# Altium schematic sheet Sensors.SchDoc(Sensors)
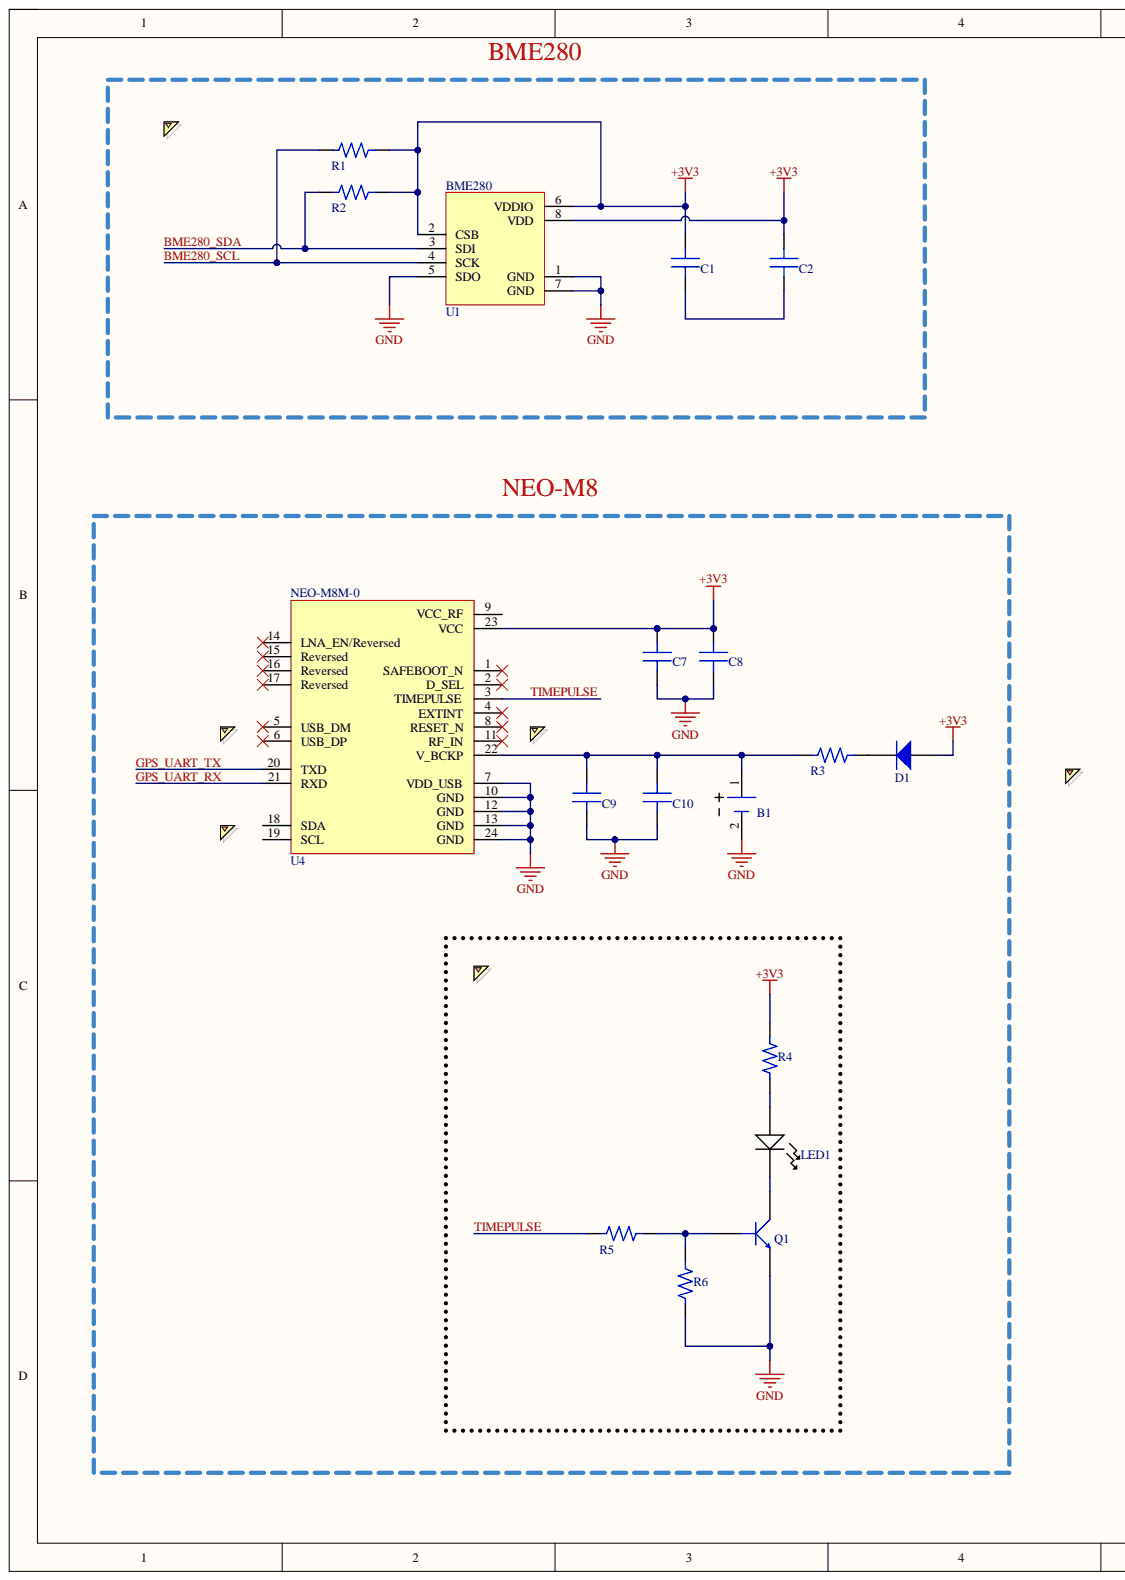
[diagram p0: Sensors.SchDoc, left]
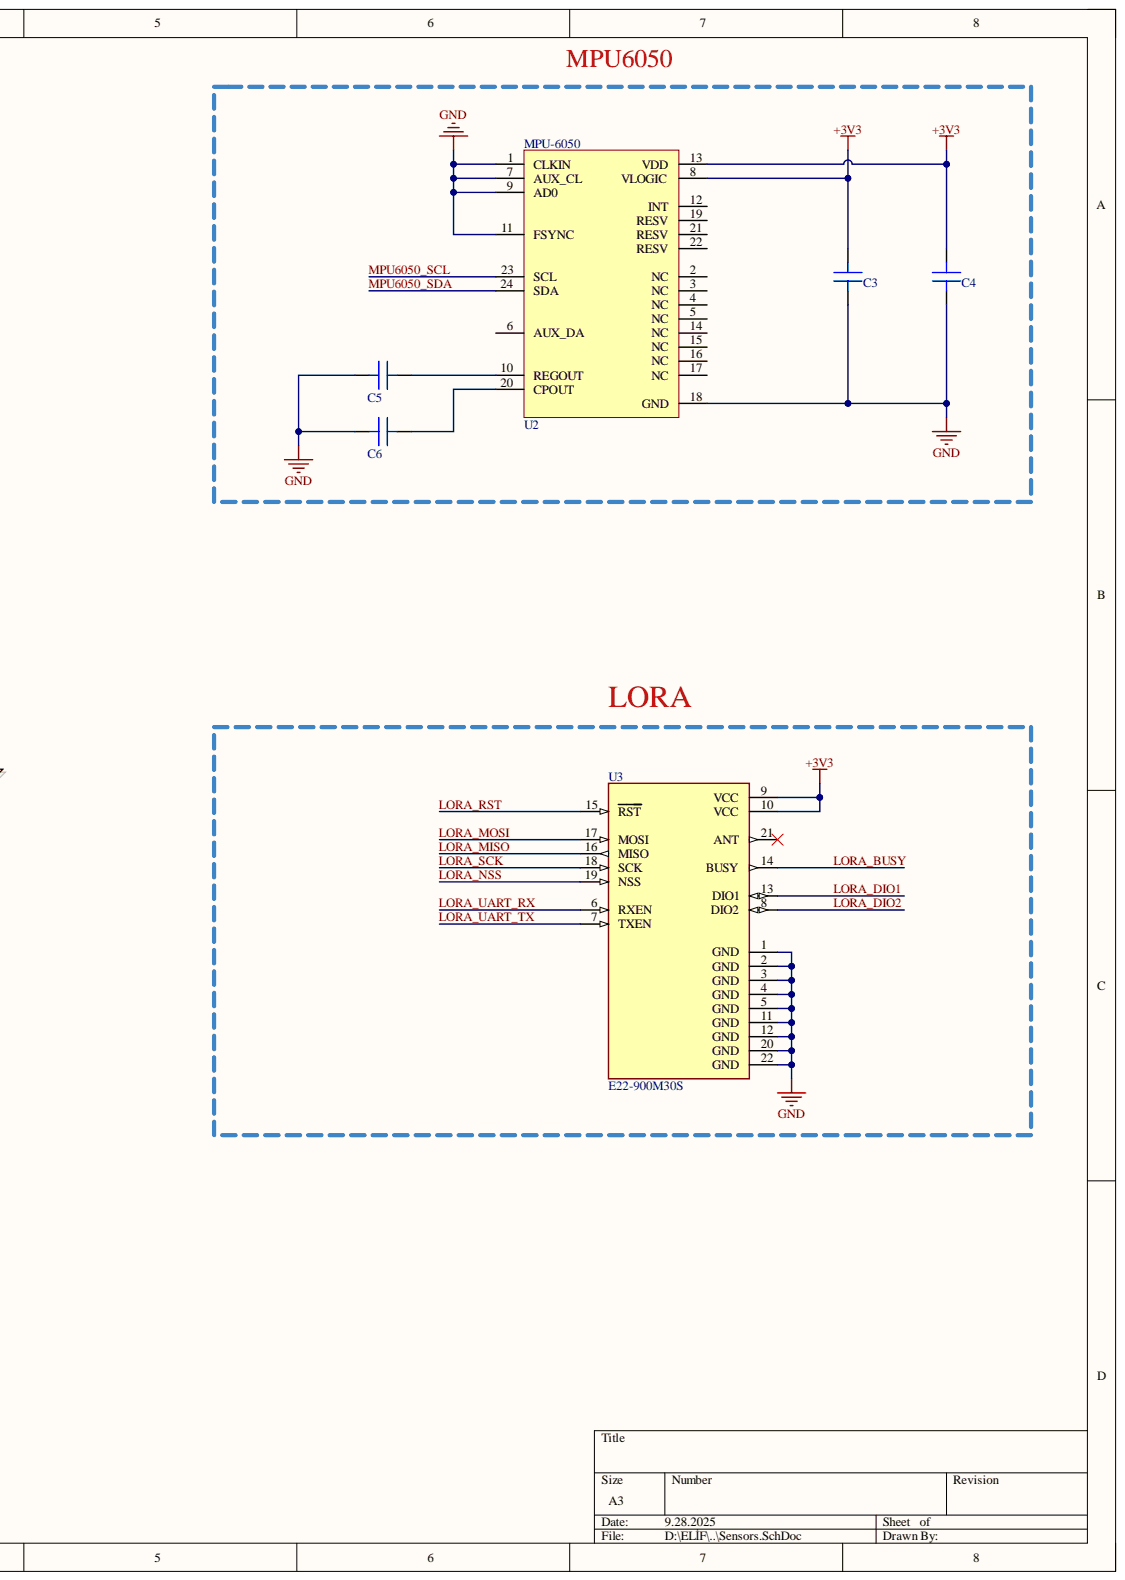
[diagram p1: Sensors.SchDoc, right]

## Parts
B1  MS920SE-FL27E  [MS920SE_Battery]  (value not drawn)
C1  CAP CER 100nF 0805  [CAP_0805]  (value not drawn)
C2  CAP CER 100nF 0805  [CAP_0805]  (value not drawn)
C3  CAP CER 10nF 0805  [CAP_0805]  (value not drawn)
C4  CAP CER 0.1uF 0805  [CAP_0805]  (value not drawn)
C5  CAP CER 0.1uF 0805  [CAP_0805]  (value not drawn)
C6  CAP CER 2.2nF 0805  [CAP_0805]  (value not drawn)
C7  CAP CER 10uF 0805  [CAP_0805]  (value not drawn)
C8  CAP CER 100nF 0805  [CAP_0805]  (value not drawn)
C9  CAP CER 4.7uF 0805  [CAP_0805]  (value not drawn)
C10  CAP CER 100nF 0805  [CAP_0805]  (value not drawn)
D1  DIODE GEN PURP 75V 150MA SOD323F  [SOD323F]  (value not drawn)
LED1  CMD17-21VRD/TR8  [LED RED_0805]  (value not drawn)
Q1  MMBT3904-7-F  [SOT_23F]  (value not drawn)
R1  RES 4.7K OHM 0805  [RES_0805]  (value not drawn)
R2  RES 4.7K OHM 0805  [RES_0805]  (value not drawn)
R3  RES 1K OHM 0805  [RES_0805]  (value not drawn)
R4  RES 1K OHM 0805  [RES_0805]  (value not drawn)
R5  RES 10K OHM 0805  [RES_0805]  (value not drawn)
R6  RES 10K OHM 0805  [RES_0805]  (value not drawn)
U1  BME280  [BME280]
U2  MPU-6050  [QFN-24-MPU6050]
U3  E22-900M30S  [LORA]
U4  NEO-M8M-0  [NEO-M8-GPS]

## Nets
+3V3: C1.2 C2.2 C3.2 C4.2 C7.2 C8.2 D1.2 R1.2 R2.2 R4.2 U1.2(CSB) U1.6(VDDIO) U1.8(VDD) U2.8(VLOGIC) U2.13(VDD) U3.9(VCC) U3.10(VCC) U4.23(VCC)
GND: B1.2 C3.1 C4.1 C5.1 C6.1 C7.1 C8.1 C9.1 C10.1 Q1.2 R6.1 U1.1(GND) U1.5(SDO) U1.7(GND) U2.1(CLKIN) U2.7(AUX_CL) U2.9(AD0) U2.11(FSYNC) U2.18(GND) U3.1(GND) U3.2(GND) U3.3(GND) U3.4(GND) U3.5(GND) U3.11(GND) U3.12(GND) U3.20(GND) U3.22(GND) U4.7(VDD_USB) U4.10(GND) U4.12(GND) U4.13(GND) U4.24(GND)
BME280_SCL: R1.1 U1.4(SCK)
BME280_SDA: R2.1 U1.3(SDI)
GPS_UART_RX: U4.21(RXD)
GPS_UART_TX: U4.20(TXD)
LORA_BUSY: U3.14(BUSY)
LORA_DIO1: U3.13(DIO1)
LORA_DIO2: U3.8(DIO2)
LORA_MISO: U3.16(MISO)
LORA_MOSI: U3.17(MOSI)
LORA_NSS: U3.19(NSS)
LORA_RST: U3.15(RST)
LORA_SCK: U3.18(SCK)
LORA_UART_RX: U3.6(RXEN)
LORA_UART_TX: U3.7(TXEN)
MPU6050_SCL: U2.23(SCL)
MPU6050_SDA: U2.24(SDA)
TIMEPULSE: R5.1 U4.3(TIMEPULSE)
NetB1_1: B1.1 C9.2 C10.2 R3.1 U4.22(V_BCKP)
NetC1_1: C1.1 C2.1
NetC5_2: C5.2 U2.10(REGOUT)
NetC6_2: C6.2 U2.20(CPOUT)
NetD1_1: D1.1 R3.2
NetLED1_1: LED1.1 R4.1
NetLED1_2: LED1.2 Q1.3
NetQ1_1: Q1.1 R5.2 R6.2

Not connected: U2 2(NC) 3(NC) 4(NC) 5(NC) 6(AUX_DA) 12(INT) 14(NC) 15(NC) 16(NC) 17(NC) 19(RESV) 21(RESV) 22(RESV); U3 21(ANT); U4 1(SAFEBOOT_N) 2(D_SEL) 4(EXTINT) 5(USB_DM) 6(USB_DP) 8(RESET_N) 9(VCC_RF) 11(RF_IN) 14(LNA_EN/Reversed) 15(Reversed) 16(Reversed) 17(Reversed) 18(SDA) 19(SCL)

## Library data of the parts
B1 = BATTERY: Lithium Battery Coin 3V 11mAh Rechargeable Tray; Manufacturer: Epson; Capacity: 0.011ah; Number of Cells: 1; Chemistry/Technology: Lithium; Component Kind: Standard; ComponentLink1Description: http://speed.sii.co.jp/data/file_PRODUCT_MASTER_50112_TITLE_IMAGESP.pdf?src-supplier=Avnet; ComponentLink1URL: http://speed.sii.co.jp/data/file_PRODUCT_MASTER_50112_TITLE_IMAGESP.pdf?src-supplier=Avnet; Manufacturer Part Number: MS920SE-FL27E; Max Operating Temperature: 60°C; Min Operating Temperature: -20°C; Mount: Screw; Pricing 1: 1=2,520000, 10=2,091000, 50=1,844000, 100=1,741000, 300=1,617400, 500=1,535000, 1000=1,452580, 2500=1,380470 (USD); RoHS: No; Stock 1: 17863; Supplier 1: Digi-Key; Supplier Part Number 1: 728-1058-ND; Voltage: 3V; Library Name: ROKET_LIBRARY.IntLib
D1 = DIODE: VR=75V IF=150mA Trr=4ns P=200mW; Manufacturer: ON Semiconductor / Fairchild; Manufacturer Part Number: 1N4148WS; Element Configuration: Single; Forward Voltage: 1V; Max Forward Surge Current (Ifsm): 2A; Max Reverse Current: 5uA; Power Dissipation: 200mW; Recovery Time: 4ns; Average Rectified Current: 150mA; Category: Rectifier Diodes; Component Kind: Standard; ComponentLink1Description: https://datasheet.ciiva.com/30479/0900766b810e1cd3-30479206.pdf?src-supplier=Rs; ComponentLink1URL: https://datasheet.ciiva.com/30479/0900766b810e1cd3-30479206.pdf?src-supplier=Rs; ComponentLink2Description: https://datasheet.ciiva.com/15208/getdatasheetpartid-127819-15208358.pdf?src-supplier=Verical; ComponentLink2URL: https://datasheet.ciiva.com/15208/getdatasheetpartid-127819-15208358.pdf?src-supplier=Verical; ComponentLink3Description: https://datasheet.ciiva.com/8300/1n914bws-8300360.pdf?src-supplier=Avnet; ComponentLink3URL: https://datasheet.ciiva.com/8300/1n914bws-8300360.pdf?src-supplier=Avnet; ComponentLink4Description: https://datasheet.ciiva.com/910/1n4148ws-910381.pdf?src-supplier=Digi-Key; ComponentLink4URL: https://datasheet.ciiva.com/910/1n4148ws-910381.pdf?src-supplier=Digi-Key; ComponentLink5Description: https://datasheet.ciiva.com/910/1n4148ws-910382.pdf?src-supplier=Digi-Key; ComponentLink5URL: https://datasheet.ciiva.com/910/1n4148ws-910382.pdf?src-supplier=Digi-Key; ComponentLink6Description: https://datasheet.ciiva.com/910/1n4148ws-910380.pdf?src-supplier=Digi-Key; ComponentLink6URL: https://datasheet.ciiva.com/910/1n4148ws-910380.pdf?src-supplier=Digi-Key; Contact Plating: Tin,Matte; Forward Current: 150mA; Height: 1mm; Lead Free: Lead Free; Length: 1.8mm; Max Operating Temperature: 150°C; Max Repetitive Reverse Voltage (Vrrm): 75V; Max Reverse Voltage (DC): 75V; Min Operating Temperature: -55°C; Mount: Surface Mount; Number of Pins: 2; Package Quantity: 1; Packaging: Tape and Reel; Pricing 1: 1=0,21000, 10=0,19200, 100=0,10460, 500=0,06436, 1000=0,04388 (USD); Radiation Hardening: No; Reach SVHC Compliant: Yes; Reverse Recovery Time: 4ns; Reverse Voltage: 75V; RoHS: Yes; RoHS Compliant: Yes; Series: -; Stock 1: 973654; Supplier 1: Digi-Key; Supplier Part Number 1: 1N4148WSFSDKR-ND; Weight: 0.0276g; Width: 1.35mm; Library Name: ROKET_LIBRARY.IntLib
LED1 = LED RED: LED Uni-Color Red 640nm 2-Pin SMT T/R; Manufacturer: VCC CHML; Forward Current: 20mA; Forward Voltage: 2V; Lens Style: Diffused,Tinted,Rectangular,Colored; Luminous Intensity: 6mcd; Number of LEDs: 1; Power Rating: 100mW; Reverse Voltage: 5V; Viewing Angle: 140°; Case Code (Metric): 2012; Case Code (Imperial): 0805; Case/Package: 0805; Category: LEDs; Color: Red; Component Kind: Standard; ComponentLink1Description: http://www.mouser.com/ds/2/423/CMD1721ver1-1064576.pdf?src-supplier=Mouser; ComponentLink1URL: http://www.mouser.com/ds/2/423/CMD1721ver1-1064576.pdf?src-supplier=Mouser; ComponentLink2Description: https://www.alliedelec.com/m/d/ea8f758becbd32522d2612194452bcae.pdf?src-supplier=Allied+Electronics; ComponentLink2URL: https://www.alliedelec.com/m/d/ea8f758becbd32522d2612194452bcae.pdf?src-supplier=Allied+Electronics; ComponentLink3Description: http://www.onlinecomponents.com/productFiles/mf-CM/2cmd17-21seriessmtleds0805packagesize.pdf?src-supplier=Onlinecomponents.com; ComponentLink3URL: http://www.onlinecomponents.com/productFiles/mf-CM/2cmd17-21seriessmtleds0805packagesize.pdf?src-supplier=Onlinecomponents.com; ComponentLink4Description: https://vcclite.com/wp-content/uploads/wpallimport/files/files/CMD1721Seriesver3.pdf?src-supplier=Digi-Key; ComponentLink4URL: https://vcclite.com/wp-content/uploads/wpallimport/files/files/CMD1721Seriesver3.pdf?src-supplier=Digi-Key; Height: 1.1mm; Lead Free: Lead Free; Length: 2mm; Lens Color: Red; Manufacturer Part Number: CMD17-21VRD/TR8; Max Operating Temperature: 85°C; Min Operating Temperature: -40°C; Mount: Surface Mount; Number of Pins: 2; Package Quantity: 1; Packaging: Tape and Reel; Pricing 1: 1=0,73000, 10=0,47800, 100=0,31310, 1000=0,24720 (USD); Reverse Voltage (DC): 5V; RoHS: Yes; RoHS Compliant: Yes; Stock 1: 357228; Supplier 1: Digi-Key; Supplier Part Number 1: L62501CT-ND; Test Current: 20mA; Wavelength: 640nm; Width: 1.25mm; Illumination Color: Red; Library Name: ROKET_LIBRARY.IntLib
Q1 = BJT_NPN: NPN Ic=200mA Vceo=40V hfe=100~300 fT=310MHz P=300mW SOT-23; Manufacturer: Diodes Zetex; Collector Base Voltage (VCBO): 60V; Collector Emitter Breakdown Voltage: 40V; Collector Emitter Saturation Voltage: 300mV; Continuous Collector Current: 200mA; Element Configuration: Single; Emitter Base Voltage (VEBO): 6V; Frequency: 300MHz; hFE Min: 100; Max Power Dissipation: 300mW; Number of Elements: 1; Power Dissipation: 350mW; Case/Package: SOT-23; Category: BJTs; Collector Emitter Voltage (VCEO): 40V; Collector-emitter Voltage-Max: 300mV; Component Kind: Standard; ComponentLink1Description: https://datasheet.ciiva.com/11201/ds30036-11201778.pdf?src-supplier=Avnet; ComponentLink1URL: https://datasheet.ciiva.com/11201/ds30036-11201778.pdf?src-supplier=Avnet; ComponentLink2Description: https://datasheet.ciiva.com/15138/getdatasheetpartid-53265-15138780.pdf?src-supplier=Verical; ComponentLink2URL: https://datasheet.ciiva.com/15138/getdatasheetpartid-53265-15138780.pdf?src-supplier=Verical; ComponentLink3Description: https://datasheet.ciiva.com/22711/1915516-22711750.pdf?src-supplier=Element14; ComponentLink3URL: https://datasheet.ciiva.com/22711/1915516-22711750.pdf?src-supplier=Element14; ComponentLink4Description: https://datasheet.ciiva.com/11198/ds30036-11198999.pdf?src-supplier=Avnet; ComponentLink4URL: https://datasheet.ciiva.com/11198/ds30036-11198999.pdf?src-supplier=Avnet; ComponentLink5Description: https://datasheet.ciiva.com/6361/ds30036-6361256.pdf?src-supplier=Digi-Key; ComponentLink5URL: https://datasheet.ciiva.com/6361/ds30036-6361256.pdf?src-supplier=Digi-Key; ComponentLink6Description: https://datasheet.ciiva.com/6361/ds30036-6361254.pdf?src-supplier=Digi-Key; ComponentLink6URL: https://datasheet.ciiva.com/6361/ds30036-6361254.pdf?src-supplier=Digi-Key; Contact Plating: Tin,Matte; Gain Bandwidth Product: 300MHz; Height: 1mm; Lead Free: Lead Free; Length: 3.05mm; Manufacturer Part Number: MMBT3904-7-F; Max Breakdown Voltage: 40V; Max Collector Current: 200mA; Max Frequency: 300MHz; Max Operating Temperature: 150°C; Min Operating Temperature: -55°C; Mount: Surface Mount; Number of Pins: 3; Package Quantity: 3000; Packaging: Tape and Reel; Polarity: NPN; Pricing 1: 1=0,13000, 10=0,11700, 100=0,06370, 500=0,03918, 1000=0,02671 (USD); Radiation Hardening: No; Reach SVHC Compliant: Yes; RoHS: Yes; RoHS Compliant: Yes; Series: Automotive, AEC-Q101; Stock 1: 7729144; Supplier 1: Digi-Key; Supplier Part Number 1: MMBT3904-FDICT-ND; Transition Frequency: 300MHz; Voltage Rating (DC): 40V; Weight: 0.000282oz; Width: 1.4mm; Library Name: ROKET_LIBRARY.IntLib
U1 = BME280: Pressure, Temperature and Humidity Sensor Digital Output 1.8V 8-Pin LGA T/R; Manufacturer: Bosch Tools; Category: Pressure Sensors; Component Kind: Standard; Manufacturer Part Number: BME280; Pricing 1: 1=7,64000, 5=6,74200, 10=5,95500, 25=4,83160, 50=4,26960, 100=4,15730, 500=3,48316, 1000=3,25844 (USD); RoHS: No; Stock 1: 180730; Supplier 1: Digi-Key; Supplier Part Number 1: 828-1063-1-ND; Library Name: ROKET_LIBRARY.IntLib
U2 = MPU6050: IMU ACCEL/GYRO 3-AXIS I2C 24QFN; Manufacturer: TDK InvenSense; Sensor Type: Accelerometer,Gyroscope,6 Axis; Case/Package: QFN; Category: Inertial Measurement Units (IMUs); Component Kind: Standard; ComponentLink1Description: https://datasheet.ciiva.com/1513/1788002-1513632.pdf?src-supplier=Element14; ComponentLink1URL: https://datasheet.ciiva.com/1513/1788002-1513632.pdf?src-supplier=Element14; ComponentLink2Description: https://datasheet.ciiva.com/22731/1788002-22731434.pdf?src-supplier=Element14; ComponentLink2URL: https://datasheet.ciiva.com/22731/1788002-22731434.pdf?src-supplier=Element14; Manufacturer Part Number: MPU-6050; Max Operating Temperature: 85°C; Min Operating Temperature: -40°C; Mount: Surface Mount; Output Type: I2C; Packaging: Cut Tape; Pricing 1: 1=9,11000, 5=7,99200, 10=7,19300, 25=6,39400, 50=5,91440, 100=5,11520, 500=4,79550, 1000=4,47580 (USD); RoHS: Yes; RoHS Compliant: Yes; Series: -; Supplier 1: Digi-Key; Supplier Part Number 1: 1428-1007-1-ND; Library Name: ROKET_LIBRARY.IntLib
U3 = LORA: SX1262 868/915 MHz 1w SPI LoRa Module; MF: EBYTE; Availability: Not in stock; Check_prices: https://www.snapeda.com/parts/E22-900M30S/EBYTE/view-part/?ref=eda; Component Kind: Standard; MP: E22-900M30S; Package: Package; Price: None; SnapEDA_Link: https://www.snapeda.com/parts/E22-900M30S/EBYTE/view-part/?ref=snap; Library Name: İSRA_ROKET.IntLib
U4 = NEO-M8: Rf Rcvr Gnss/gps 1.575GHZ 24LCC; Manufacturer: u-blox; Current - Receiving: 21mA; Sensitivity: -167dBm; Interface: I2C,SPI,USB,UART; Case/Package: SMD/SMT; Category: RF Receivers, Transceivers; Component Kind: Standard; ComponentLink1Description: https://www.u-blox.com/sites/default/files/products/documents/NEO-M8-FW3_ProductSummary_%28UBX-16000345%29.pdf?src-supplier=Digi-Key; ComponentLink1URL: https://www.u-blox.com/sites/default/files/products/documents/NEO-M8-FW3_ProductSummary_%28UBX-16000345%29.pdf?src-supplier=Digi-Key; ComponentLink2Description: https://www.u-blox.com/sites/default/files/NEO-M8-FW3_DataSheet_%28UBX-15031086%29.pdf?src-supplier=Digi-Key; ComponentLink2URL: https://www.u-blox.com/sites/default/files/NEO-M8-FW3_DataSheet_%28UBX-15031086%29.pdf?src-supplier=Digi-Key; Frequency: 1.575GHz; Manufacturer Part Number: NEO-M8M-0; Max Operating Temperature: 85°C; Min Operating Temperature: -40°C; Packaging: Tape and Reel; Pricing 1: 1=27,00000, 10=19,61000, 50=16,10000, 100=12,89000 (USD); RoHS: Yes; Stock 1: 3000; Supplier 1: Digi-Key; Supplier Part Number 1: 672-NEO-M8M-0CT-ND; Library Name: ROKET_LIBRARY.IntLib
C1 C2 C8 C10 = CAP CER 100nF 0805: Component Kind: Standard; Library Name: ROKET_LIBRARY.IntLib
C3 = CAP CER 10nF 0805: Component Kind: Standard; Library Name: ROKET_LIBRARY.IntLib
C4 C5 = CAP CER 0.1uF 0805: Component Kind: Standard; Library Name: ROKET_LIBRARY.IntLib
C6 = CAP CER 2.2nF 0805: Component Kind: Standard; Library Name: ROKET_LIBRARY.IntLib
C7 = CAP CER 10uF 0805: Component Kind: Standard; Library Name: ROKET_LIBRARY.IntLib
C9 = CAP CER 4.7uF 0805: Component Kind: Standard; Library Name: ROKET_LIBRARY.IntLib
R1 R2 = RES 4.7K OHM 0805: Component Kind: Standard; Library Name: ROKET_LIBRARY.IntLib
R3 R4 = RES 1K OHM 0805: Component Kind: Standard; Library Name: ROKET_LIBRARY.IntLib
R5 R6 = RES 10K OHM 0805: Component Kind: Standard; Library Name: ROKET_LIBRARY.IntLib
